# Altium schematic sheet 1_CONNECTORS.SchDoc(1_CONNECTORS)
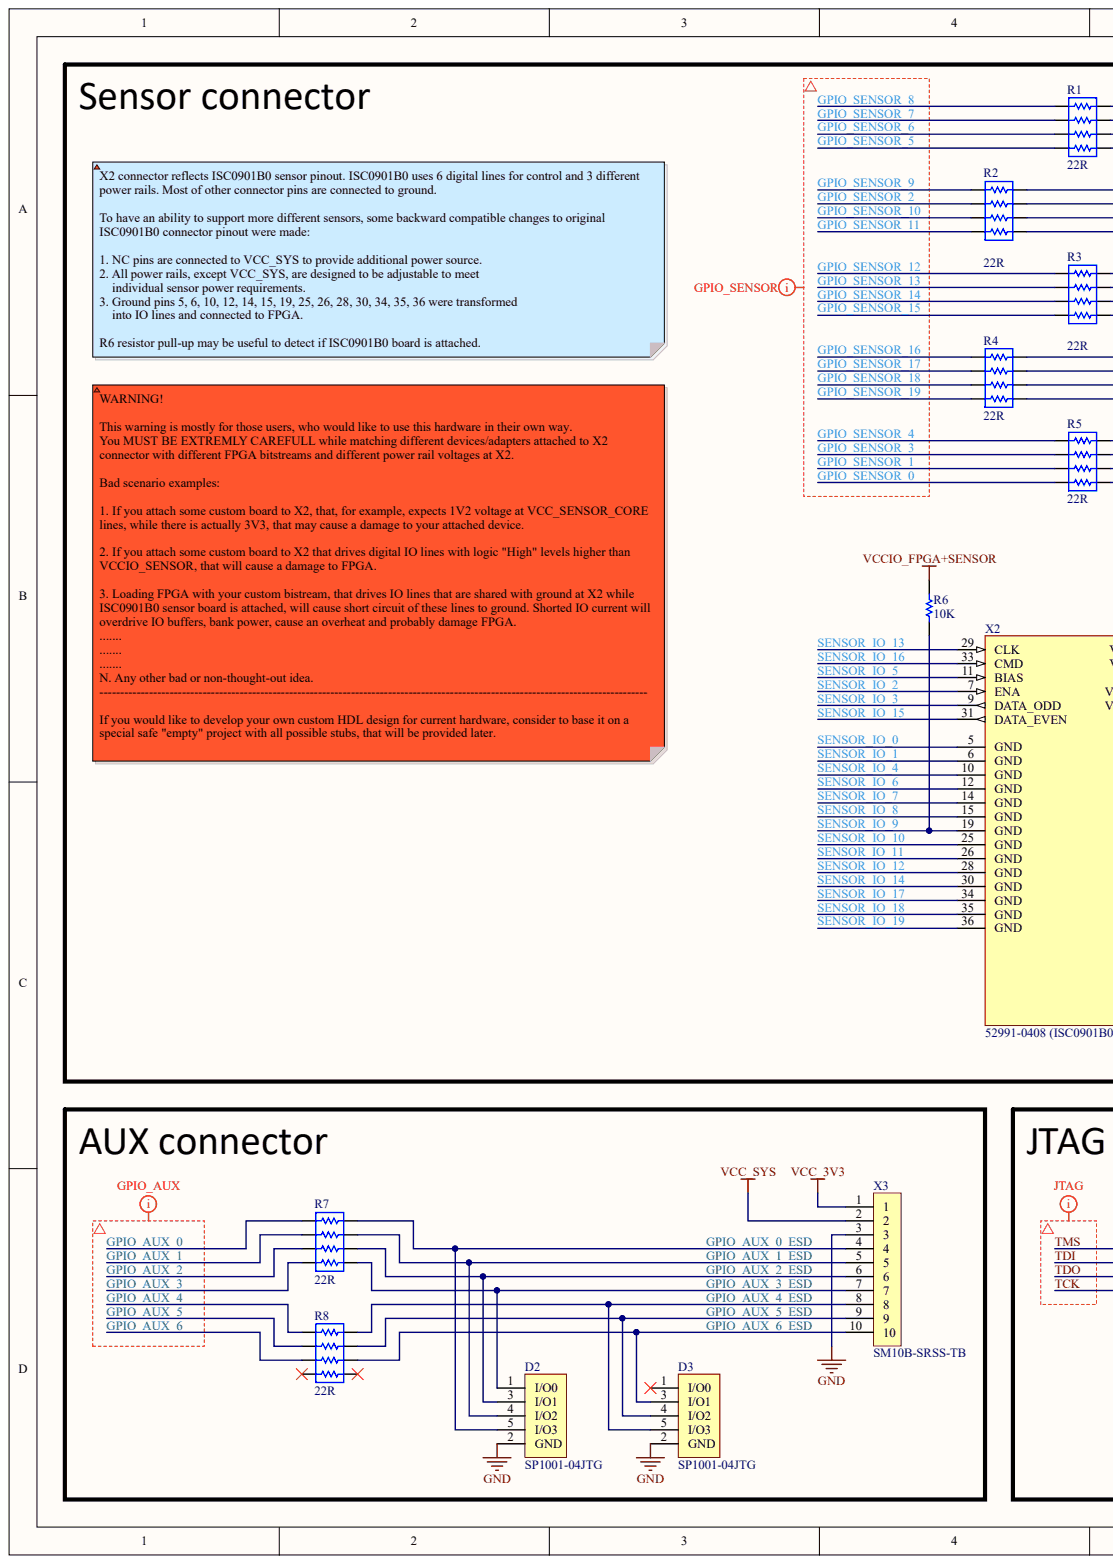
[diagram p0: 1_CONNECTORS.SchDoc, left]
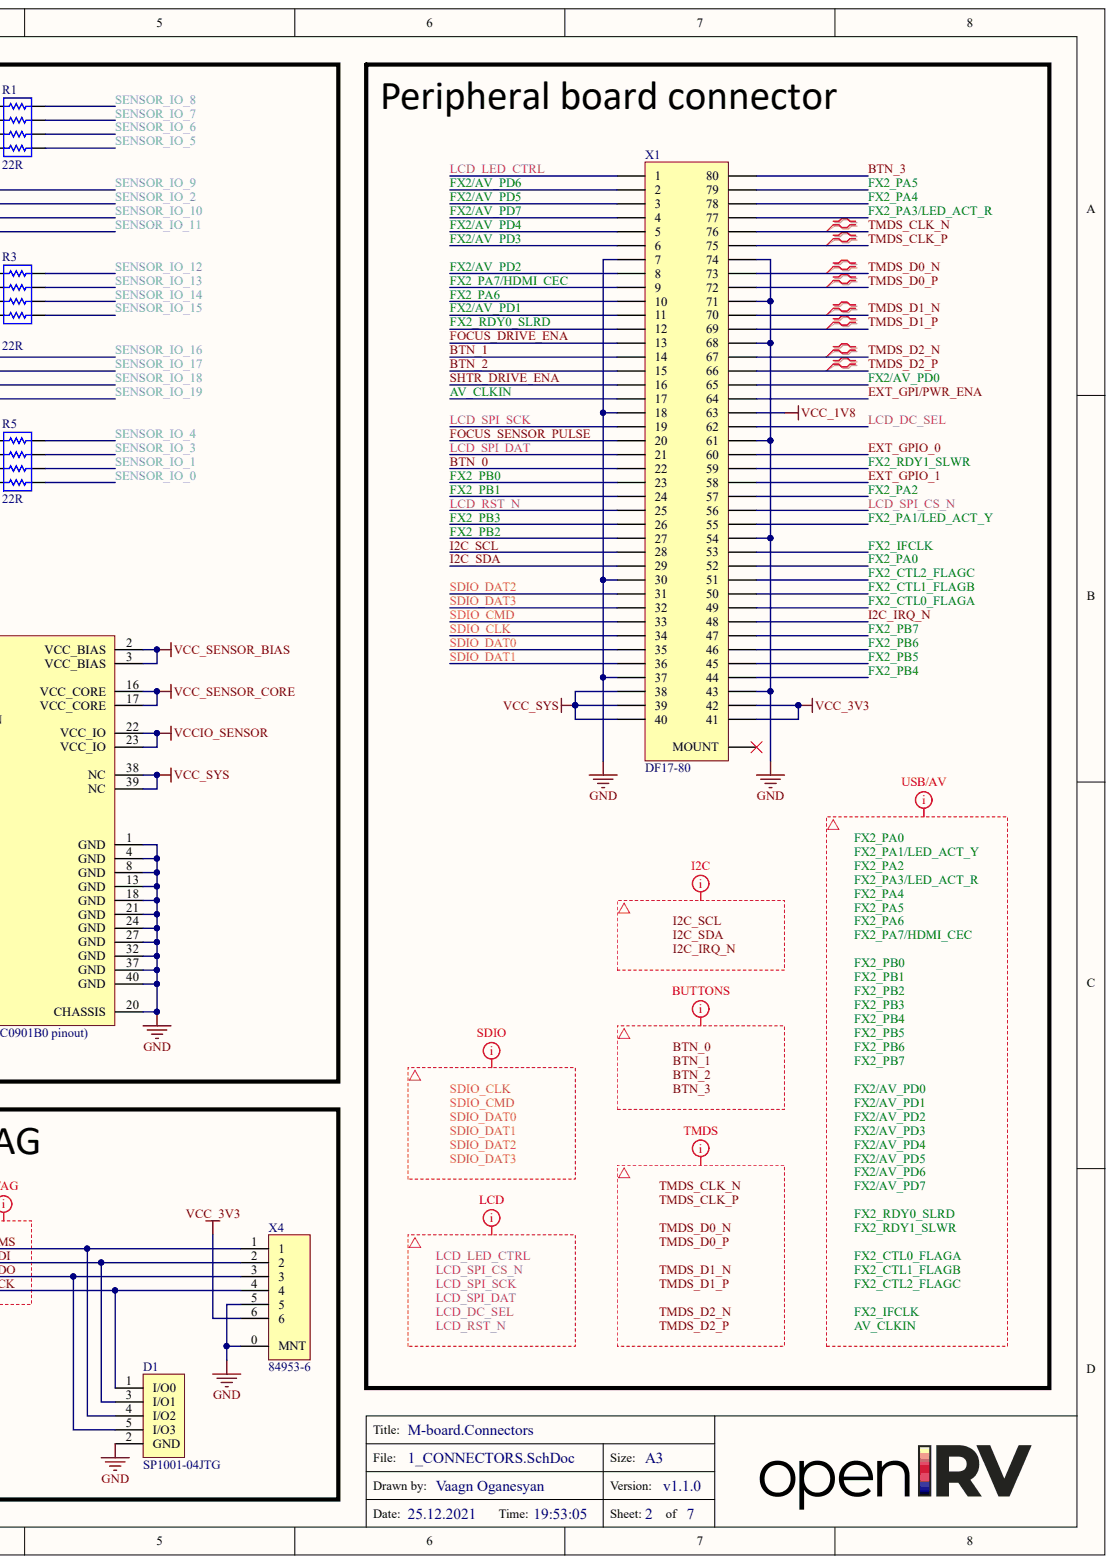
[diagram p1: 1_CONNECTORS.SchDoc, right]

## Parts
D1  SP1001-04JTG  [SP1001-04JTG]
D2  SP1001-04JTG  [SP1001-04JTG]
D3  SP1001-04JTG  [SP1001-04JTG]
R1  22R  [R0804]
R2  22R  [R0804]
R3  22R  [R0804]
R4  22R  [R0804]
R5  22R  [R0804]
R6  10K  [R0402[1005]]
R7  22R  [R0804]
R8  22R  [R0804]
X1  DF17-80  [DF17(2.0)-80DP-0.5V(57)]
X2  52991-0408 (ISC0901B0 pinout)  [52991-0408]
X3  SM10B-SRSS-TB  [SM10B-SRSS-TB]
X4  84953-6  [84953-6]

## Nets
GND: D1.2(GND) D2.2(GND) D3.2(GND) X1.7 X1.18 X1.30 X1.37 X1.43 X1.54 X1.61 X1.68 X1.71 X1.74 X2.1(GND) X2.4(GND) X2.8(GND) X2.13(GND) X2.18(GND) X2.20(CHASSIS) X2.21(GND) X2.24(GND) X2.27(GND) X2.32(GND) X2.37(GND) X2.40(GND) X3.3 X4.0(MNT) X4.5
VCC_1V8: X1.63
VCC_3V3: X1.41 X1.42 X3.1 X4.6
VCC_SENSOR_BIAS: X2.2(VCC_BIAS) X2.3(VCC_BIAS)
VCC_SENSOR_CORE: X2.16(VCC_CORE) X2.17(VCC_CORE)
VCC_SYS: X1.38 X1.39 X1.40 X2.38(NC) X2.39(NC) X3.2
VCCIO_FPGA+SENSOR: R6.2
VCCIO_SENSOR: X2.22(VCC_IO) X2.23(VCC_IO)
AV_CLKIN: X1.17
BTN_0: X1.22
BTN_1: X1.14
BTN_2: X1.15
BTN_3: X1.80
EXT_GPI/PWR_ENA: X1.64
EXT_GPIO_0: X1.60
EXT_GPIO_1: X1.58
FOCUS_DRIVE_ENA: X1.13
FOCUS_SENSOR_PULSE: X1.20
FX2/AV_PD0: X1.65
FX2/AV_PD1: X1.11
FX2/AV_PD2: X1.8
FX2/AV_PD3: X1.6
FX2/AV_PD4: X1.5
FX2/AV_PD5: X1.3
FX2/AV_PD6: X1.2
FX2/AV_PD7: X1.4
FX2_CTL0_FLAGA: X1.49
FX2_CTL1_FLAGB: X1.50
FX2_CTL2_FLAGC: X1.51
FX2_IFCLK: X1.53
FX2_PA0: X1.52
FX2_PA1/LED_ACT_Y: X1.55
FX2_PA2: X1.57
FX2_PA3/LED_ACT_R: X1.77
FX2_PA4: X1.78
FX2_PA5: X1.79
FX2_PA6: X1.10
FX2_PA7/HDMI_CEC: X1.9
FX2_PB0: X1.23
FX2_PB1: X1.24
FX2_PB2: X1.27
FX2_PB3: X1.26
FX2_PB4: X1.44
FX2_PB5: X1.45
FX2_PB6: X1.46
FX2_PB7: X1.47
FX2_RDY0_SLRD: X1.12
FX2_RDY1_SLWR: X1.59
GPIO_AUX_0: R7.5
GPIO_AUX_0_ESD: D2.5(I/O3) R7.4 X3.4
GPIO_AUX_1: R7.6
GPIO_AUX_1_ESD: D2.4(I/O2) R7.3 X3.5
GPIO_AUX_2: R7.7
GPIO_AUX_2_ESD: D2.3(I/O1) R7.2 X3.6
GPIO_AUX_3: R7.8
GPIO_AUX_3_ESD: D2.1(I/O0) R7.1 X3.7
GPIO_AUX_4: R8.5
GPIO_AUX_4_ESD: D3.5(I/O3) R8.4 X3.8
GPIO_AUX_5: R8.6
GPIO_AUX_5_ESD: D3.4(I/O2) R8.3 X3.9
GPIO_AUX_6: R8.7
GPIO_AUX_6_ESD: D3.3(I/O1) R8.2 X3.10
GPIO_SENSOR_0: R5.8
GPIO_SENSOR_1: R5.7
GPIO_SENSOR_2: R2.6
GPIO_SENSOR_3: R5.6
GPIO_SENSOR_4: R5.5
GPIO_SENSOR_5: R1.8
GPIO_SENSOR_6: R1.7
GPIO_SENSOR_7: R1.6
GPIO_SENSOR_8: R1.5
GPIO_SENSOR_9: R2.5
GPIO_SENSOR_10: R2.7
GPIO_SENSOR_11: R2.8
GPIO_SENSOR_12: R3.5
GPIO_SENSOR_13: R3.6
GPIO_SENSOR_14: R3.7
GPIO_SENSOR_15: R3.8
GPIO_SENSOR_16: R4.5
GPIO_SENSOR_17: R4.6
GPIO_SENSOR_18: R4.7
GPIO_SENSOR_19: R4.8
I2C_IRQ_N: X1.48
I2C_SCL: X1.28
I2C_SDA: X1.29
LCD_DC_SEL: X1.62
LCD_LED_CTRL: X1.1
LCD_RST_N: X1.25
LCD_SPI_CS_N: X1.56
LCD_SPI_DAT: X1.21
LCD_SPI_SCK: X1.19
SDIO_CLK: X1.34
SDIO_CMD: X1.33
SDIO_DAT0: X1.35
SDIO_DAT1: X1.36
SDIO_DAT2: X1.31
SDIO_DAT3: X1.32
SENSOR_IO_0: R5.1 X2.5(GND)
SENSOR_IO_1: R5.2 X2.6(GND)
SENSOR_IO_2: R2.3 X2.7(ENA)
SENSOR_IO_3: R5.3 X2.9(DATA_ODD)
SENSOR_IO_4: R5.4 X2.10(GND)
SENSOR_IO_5: R1.1 X2.11(BIAS)
SENSOR_IO_6: R1.2 X2.12(GND)
SENSOR_IO_7: R1.3 X2.14(GND)
SENSOR_IO_8: R1.4 X2.15(GND)
SENSOR_IO_9: R2.4 R6.1 X2.19(GND)
SENSOR_IO_10: R2.2 X2.25(GND)
SENSOR_IO_11: R2.1 X2.26(GND)
SENSOR_IO_12: R3.4 X2.28(GND)
SENSOR_IO_13: R3.3 X2.29(CLK)
SENSOR_IO_14: R3.2 X2.30(GND)
SENSOR_IO_15: R3.1 X2.31(DATA_EVEN)
SENSOR_IO_16: R4.4 X2.33(CMD)
SENSOR_IO_17: R4.3 X2.34(GND)
SENSOR_IO_18: R4.2 X2.35(GND)
SENSOR_IO_19: R4.1 X2.36(GND)
SHTR_DRIVE_ENA: X1.16
TCK: D1.1(I/O0) X4.4
TDI: D1.3(I/O1) X4.2
TDO: D1.5(I/O3) X4.3
TMDS_CLK_N: X1.76
TMDS_CLK_P: X1.75
TMDS_D0_N: X1.73
TMDS_D0_P: X1.72
TMDS_D1_N: X1.70
TMDS_D1_P: X1.69
TMDS_D2_N: X1.67
TMDS_D2_P: X1.66
TMS: D1.4(I/O2) X4.1
N00085:
N00086:
N00087:
N00088:
N00089:
N00090:
N00091:
N00092:
N00093:

Not connected: D3 1(I/O0); R8 1 8; X1 0(MOUNT)

## Library data of the parts
D1-D3 = SP1001-04JTG: ESD protection diode; Component Kind: Standard; Library Name: general.IntLib
R1-R5 R7 R8 = RES_ARRAY: Power: 0.06W; Tolerance: 5%; Component Kind: Standard; Library Name: general.IntLib; Mounting: SMD; Value: 22R
R6 = RES: Power: 0.06W; Tolerance: 5%; Component Kind: Standard; Library Name: general.IntLib; Mounting: SMD; Value: 10K
X2 = 52991-0408: Molex 40 pin receptacle; Component Kind: Standard; Library Name: general.IntLib
X1 = DF17-80: Component Kind: Standard; Library Name: general.IntLib
X3 = SM10B-SRSS-TB: Component Kind: Standard; Library Name: general.IntLib
X4 = 84953-6: Component Kind: Standard; Library Name: general.IntLib
